# Altium schematic sheet [08] - CAN INTERFACE.SchDoc("[08] - CAN INTERFACE")
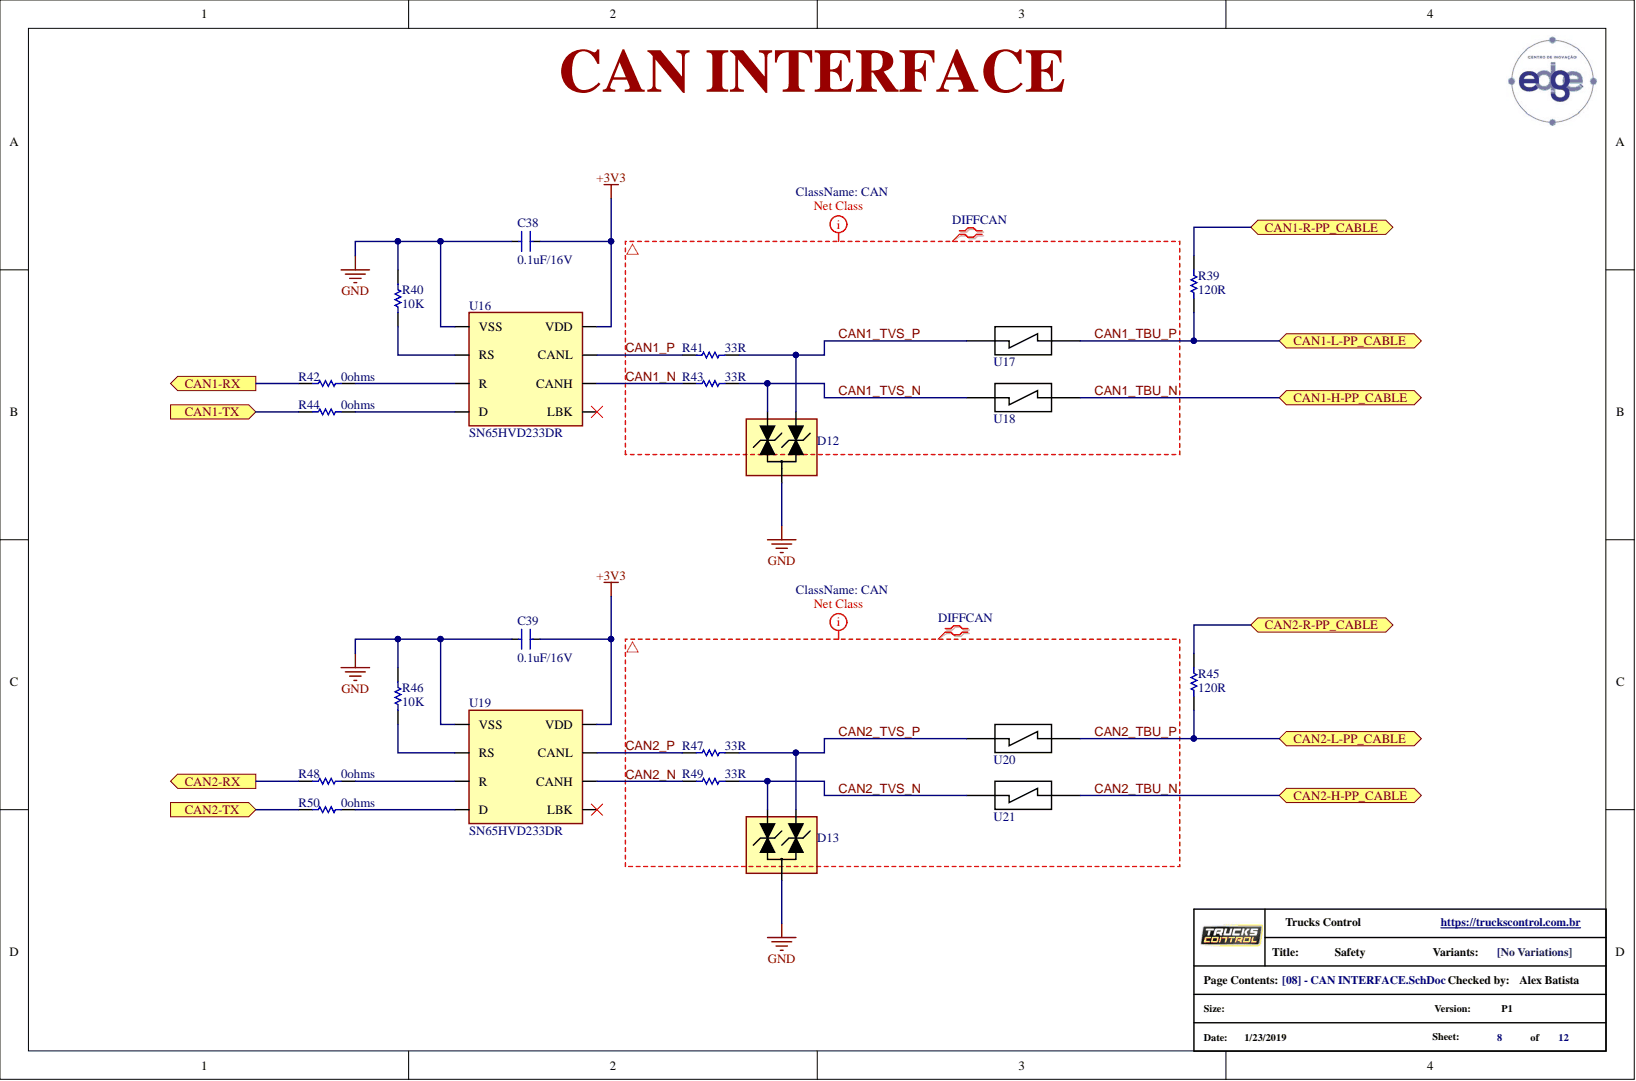
[diagram p0: [08] - CAN INTERFACE.SchDoc]

## Parts
C38  0.1uF/16V  [C0402]
C39  0.1uF/16V  [C0402]
D12  TVS Diode  [SOT-23]  (value not drawn)
D13  TVS Diode  [SOT-23]  (value not drawn)
R39  120R  [R0402]
R40  10K  [R0402]
R41  33R  [R0402]
R42  0ohms  [R0402]
R43  33R  [R0402]
R44  0ohms  [R0402]
R45  120R  [R0402]
R46  10K  [R0402]
R47  33R  [R0402]
R48  0ohms  [R0402]
R49  33R  [R0402]
R50  0ohms  [R0402]
U16  SN65HVD233DR  Case/Package: D  [SN65HVD233DR]
U17  TBU  [DFN-3]  (value not drawn)
U18  TBU  [DFN-3]  (value not drawn)
U19  SN65HVD233DR  Case/Package: D  [SN65HVD233DR]
U20  TBU  [DFN-3]  (value not drawn)
U21  TBU  [DFN-3]  (value not drawn)

## Ports
CAN1-H-PP_CABLE, CAN1-L-PP_CABLE, CAN1-R-PP_CABLE, CAN1-RX, CAN1-TX, CAN2-H-PP_CABLE, CAN2-L-PP_CABLE, CAN2-R-PP_CABLE, CAN2-RX, CAN2-TX

## Nets
+3V3: C38.1 C39.1 U16.3(VDD) U19.3(VDD)
GND: C38.2 C39.2 D12.3 D13.3 R40.2 R46.2 U16.2(VSS) U19.2(VSS)
CAN1-R-PP_CABLE: R39.2 ; port CAN1-R-PP_CABLE
CAN1-RX: R42.1 ; port CAN1-RX
CAN1-TX: R44.1 ; port CAN1-TX
CAN1_N: R43.1 U16.7(CANH)
CAN1_P: R41.1 U16.6(CANL)
CAN1_TBU_N: U18.3 ; port CAN1-H-PP_CABLE
CAN1_TBU_P: R39.1 U17.3 ; port CAN1-L-PP_CABLE
CAN1_TVS_N: D12.1 R43.2 U18.1
CAN1_TVS_P: D12.2 R41.2 U17.1
CAN2-R-PP_CABLE: R45.2 ; port CAN2-R-PP_CABLE
CAN2-RX: R48.1 ; port CAN2-RX
CAN2-TX: R50.1 ; port CAN2-TX
CAN2_N: R49.1 U19.7(CANH)
CAN2_P: R47.1 U19.6(CANL)
CAN2_TBU_N: U21.3 ; port CAN2-H-PP_CABLE
CAN2_TBU_P: R45.1 U20.3 ; port CAN2-L-PP_CABLE
CAN2_TVS_N: D13.1 R49.2 U21.1
CAN2_TVS_P: D13.2 R47.2 U20.1
NetR40_1: R40.1 U16.8(RS)
NetR42_2: R42.2 U16.4(R)
NetR44_2: R44.2 U16.1(D)
NetR46_1: R46.1 U19.8(RS)
NetR48_2: R48.2 U19.4(R)
NetR50_2: R50.2 U19.1(D)
N00174:
N00175:
N00176:
N00177:

Not connected: U16 5(LBK); U19 5(LBK)

## Library data of the parts
C38 C39 = GCM155R71C104KA55D: 0.1UF 16V X7R 0402 CAP CER; Manufacturer: Murata; Tolerance: 10%; Capacitance: 100nF; Width: 0.02inch; Voltage Rating (DC): 16V; Voltage Rating: 16V; Voltage: 16V; Thickness: 0.022inch; Termination: SMD/SMT; Supplier Part Number 1: 81-GCM155R71C104KA5D; Supplier 1: Mouser; Stock 1: 3750168; REACH SVHC: No SVHC; Ratings: AEC-Q200; Radiation Hardening: No; Pricing 1: 1=0.1, 10=0.061, 50=0.061, 100=0.026, 1000=0.015, 10000=0.01 (USD); Packaging: Tape and Reel; Package Quantity: 1; Mount: Surface Mount; Min Operating Temperature: -55°C; Max Operating Temperature: 125°C; Manufacturer Part Number: GCM155R71C104KA55D; Length: 1mm; Lead Free: Lead Free; Height: 0.5mm; Dielectric: X7R; Depth: 0.5mm; Component Kind: Standard; Case Code (Metric): 1005; Case Code (Imperial): 0402; Case/Package: 0402; Library Name: tc-pcb-safety_V1I1.SchLib
D12 D13 = CDSOT23-SM712: TVS DIODE 7VWM/12VWM SOT23; Manufacturer: Bourns; Working Voltage: 12V; Test Current: 1mA; Reverse Breakdown Voltage: 13.3V; Power Line Protection: No; Power Dissipation: 400W; Polarity: Bidirectional,Unidirectional; Peak Pulse Current: 17A; Number of Elements: 2; Max Surge Current: 30A; Max Reverse Leakage Current: 20uA; Max Power Dissipation: 600W; ESD Protection: Yes; Element Configuration: Dual; Direction: Bidirectional; Clamping Voltage: 26V; Capacitance: 75pF; Width: 0.055inch; Termination: SMD/SMT; Supplier Part Number 1: 652-CDSOT23-SM712; Supplier 1: Mouser; Stock 1: 8506; Reverse Standoff Voltage: 12V; REACH SVHC: No SVHC; Radiation Hardening: No; Pricing 1: 1=1.7, 10=1.37, 50=1.37, 100=1.05, 1000=0.731, 10000=0.63 (USD); Peak Pulse Power: 400W; Packaging: Tape and Reel; Package Quantity: 1; Number of Pins: 3; Number of Channels: 2; Number of Bidirectional Channels: 2; Mount: Surface Mount; Min Operating Temperature: -55°C; Min Breakdown Voltage: 13.3V; Max Operating Temperature: 150°C; Max Breakdown Voltage: 13.3V; Manufacturer Part Number: CDSOT23-SM712; Length: 3.04mm; Leakage Current: 20uA; Height: 1.11mm; Depth: 1.4mm; Contact Plating: Tin; Composition: Zener; Component Kind: Standard; Case/Package: SOT-23; Breakdown Voltage: 13.3V; Library Name: tc-pcb-safety_V1I1.SchLib
R39 R45 = RC0402FR-07120RL: 120 OHM 1% 50V 1/16W 0402; Manufacturer: Yageo; Temperature Coefficient: 100ppm/°C; Power Rating: 62.5mW; Max Power Dissipation: 63mW; Width: 0.02inch; Voltage Rating: 50V; Tolerance: 1%; Termination: SMD/SMT; Supplier Part Number 2: 311-120LRCT-ND; Supplier Part Number 1: 603-RC0402FR-07120RL; Supplier 2: Digi-Key; Supplier 1: Mouser; Stock 2: 1301674; Stock 1: 14774; RoHS: Yes; Resistance: 120R; REACH SVHC: No SVHC; Pricing 2: 1 = 0.10000, 10 = 0.01600, 100 = 0.00670, 1000 = 0.00301, 2500 = 0.00261, 5000 = 0.00215 (USD); Pricing 1: 1 = 0.10000, 10 = 0.01200, 50 = 0.01200, 100 = 0.00400, 1000 = 0.00300, 10000 = 0.00300 (USD); Packaging: Tape and Reel; Package Quantity: 1; Number of Terminations: 2; Min Operating Temperature: -55°C; Military Standard: Not; Max Operating Temperature: 155°C; Manufacturer Part Number: RC0402FR-07120RL; Length: 1mm; Lead Free: Lead Free; Height: 0.016inch; Features: Moisture Resistant; Depth: 0.5mm; Composition: Thick Film; ComponentLink6URL: http://www.yageo.com/documents/recent/PYu-RC0402_51_RoHS_L_6_r.pdf; ComponentLink6Description: http://www.yageo.com/documents/recent/PYu-RC0402_51_RoHS_L_6_r.pdf; ComponentLink5URL: http://www.yageo.com/documents/recent/PYu-RC_Group_51_RoHS_L_10.pdf; ComponentLink5Description: http://www.yageo.com/documents/recent/PYu-RC_Group_51_RoHS_L_10.pdf; ComponentLink4URL: http://www.yageo.com/documents/recent/PYu-RC_Group_51_RoHS_L_9.pdf; ComponentLink4Description: http://www.yageo.com/documents/recent/PYu-RC_Group_51_RoHS_L_9.pdf; ComponentLink3URL: http://documents.rs-components.com/techlib://itc_doclist_GB.htm?stockno=6185898; ComponentLink3Description: http://documents.rs-components.com/techlib://itc_doclist_GB.htm?stockno=6185898; ComponentLink2URL: http://www.mouser.com/ds/2/447/PYu-RC0402_51_RoHS_L_6_r-8721.pdf; ComponentLink2Description: http://www.mouser.com/ds/2/447/PYu-RC0402_51_RoHS_L_6_r-8721.pdf; ComponentLink1URL: http://www.farnell.com/datasheets/1018594.pdf; ComponentLink1Description: http://www.farnell.com/datasheets/1018594.pdf; Component Kind: Standard; Case Code (Metric): 1005; Case Code (Imperial): 0402; Case/Package: 0402; Library Name: tc-pcb-safety_V1I1.SchLib
R40 R46 = RC0402JR-7D10KL: 10K OHM 5% 1/16W 0402; Manufacturer: Yageo; Supplier Part Number 1: 603-RC0402JR-7D10KL; Supplier 1: Mouser; Stock 1: 178452; Pricing 1: 1=0.1, 10=0.01, 50=0.01, 100=0.004, 1000=0.003, 10000=0.002 (USD); Manufacturer Part Number: RC0402JR-7D10KL; Component Kind: Standard; Library Name: tc-pcb-safety_V1I1.SchLib
R41 R43 R47 R49 = RC0402JR-0733RL: 33 OHM 50V 5% 1/16W 0402; Manufacturer: Yageo; Temperature Coefficient: 100ppm/°C; Power Rating: 62.5mW; Max Power Dissipation: 63mW; Width: 0.5mm; Voltage Rating: 50V; Tolerance: 5%; Termination: SMD/SMT; Supplier Part Number 2: 311-33JRCT-ND; Supplier Part Number 1: 603-RC0402JR-0733RL; Supplier 2: Digi-Key; Supplier 1: Mouser; Stock 2: 5669528; Stock 1: 50; RoHS: Yes; Resistance: 33R; REACH SVHC: Unknown; Pricing 2: 1 = 0.10000, 10 = 0.01300, 100 = 0.00550, 1000 = 0.00245, 2500 = 0.00212, 5000 = 0.00176 (USD); Pricing 1: 1 = 0.10000, 10 = 0.01000, 50 = 0.01000, 100 = 0.00400, 1000 = 0.00300, 10000 = 0.00200 (USD); Packaging: Tape and Reel; Package Quantity: 1; Number of Terminations: 2; Min Operating Temperature: -55°C; Military Standard: Not; Max Operating Temperature: 155°C; Manufacturer Part Number: RC0402JR-0733RL; Length: 1mm; Lead Free: Lead Free; Height: 0.016inch; Features: Moisture Resistant; Depth: 0.5mm; Composition: Thick Film; ComponentLink3URL: http://www.yageo.com/documents/recent/PYu-RC_Group_51_RoHS_L_10.pdf; ComponentLink3Description: http://www.yageo.com/documents/recent/PYu-RC_Group_51_RoHS_L_10.pdf; ComponentLink2URL: http://www.farnell.com/datasheets/1018594.pdf; ComponentLink2Description: http://www.farnell.com/datasheets/1018594.pdf; ComponentLink1URL: http://www.yageo.com/documents/recent/PYu-RC0402_51_RoHS_L_6_r.pdf; ComponentLink1Description: http://www.yageo.com/documents/recent/PYu-RC0402_51_RoHS_L_6_r.pdf; Component Kind: Standard; Case Code (Metric): 1005; Case Code (Imperial): 0402; Case/Package: 0402; Library Name: tc-pcb-safety_V1I1.SchLib
R42 R44 R48 R50 = CRG0402ZR: 0 ohm, 50 V, 0402 [1005 Metric], 63 mW, CRG Series; Manufacturer: TE Connectivity; Voltage Rating (DC): 50V; Tolerance: 0%; Temperature Coefficient: 200ppm/°C; Resistance: 0mR; Power Rating: 63mW; Number of Resistors: 1; Insulation Resistance: 1GR; Width: 0.5mm; Weight: 2.3E-05oz; Voltage Rating: 50V; Termination: Solder; Supplier Part Number 1: 279-CRG0402ZR; Supplier 1: Mouser; Stock 1: 540000; REACH SVHC: Unknown; Radiation Hardening: No; Pricing 1: 10000=0.002 (USD); Packaging: Tape and Reel; Package Quantity: 10000; Number of Terminations: 2; Number of Pins: 2; Mount: Surface Mount; Min Operating Temperature: -55°C; Max Operating Temperature: 125°C; Manufacturer Part Number: CRG0402ZR; Length: 1mm; Height: 0.35mm; ELV: Compliant; Depth: 0.5mm; Composition: Thick Film; Component Kind: Standard; Case Code (Metric): 1005; Case Code (Imperial): 0402; Case/Package: 0402; Library Name: tc-pcb-safety_V1I1.SchLib
U16 U19 = SN65HVD233DR: 3.3V CAN Transceiver with Standby Mode, Loop-back, 6 mA, -40 to 125 degC, 8-pin SOIC (D), Green (RoHS & no Sb/Br); Manufacturer: Texas Instruments; Simplex/Duplex: Half Duplex; Receiver Hysteresis: 100mV; Number of Transmit Buffers: 1; Nominal Supply Current: 6mA; Min Supply Voltage: 3V; Max Supply Voltage: 3.6V; Data Rate: 1Mbps; Weight: 0.002561oz; Supplier Part Number 2: 296-35967-1-ND; Supplier Part Number 1: 595-SN65HVD233DR; Supplier 2: Digi-Key; Supplier 1: Mouser; Stock 2: 65613; Stock 1: 46137; RoHS: Yes; REACH SVHC: No SVHC; Radiation Hardening: No; Pricing 2: 1 = 2.69000, 10 = 2.41700, 100 = 1.98040, 500 = 1.68586, 1000 = 1.42181 (USD); Pricing 1: 1 = 2.91000, 10 = 2.47000, 50 = 2.47000, 100 = 1.98000, 1000 = 1.43000, 10000 = 1.29000 (USD); Packaging: Tape and Reel; Package Quantity: 2500; Operating Supply Voltage: 3.3V; Number of Transceivers: 1; Number of Receivers: 1; Number of Receive Buffers: 1; Number of Pins: 8; Number of Drivers: 1; Min Operating Temperature: -40°C; Max Supply Current: 6mA; Max Operating Temperature: 125°C; Manufacturer Part Number: SN65HVD233DR; Lead Free: Lead Free; Contact Plating: Gold; ComponentLink1URL: http://www.ti.com/lit/ds/symlink/sn65hvd233.pdf; ComponentLink1Description: http://www.ti.com/lit/ds/symlink/sn65hvd233.pdf; Component Kind: Standard; Library Name: tc-pcb-safety_V1I1.SchLib
U17 U18 U20 U21 = TBU-CA025-050-WH: SURGE SUPP TBU 50MA 250VIMP SMD; Manufacturer: Bourns; Working Voltage: 100V; Voltage Rating (DC): 250V; Reverse Standoff Voltage: 20V; Response Time: 1us; Peak Pulse Current: 100mA; Number of Circuits: 2; ESD Protection: Yes; Element Configuration: Single; Direction: Bidirectional; Width: 0.157inch; Weight: 0.076oz; Voltage Rating: 250V; Termination: Solder; Supplier Part Number 1: 652-TBU-CA025-050-WH; Supplier 1: Mouser; Stock 1: 23479; REACH SVHC: No SVHC; Pricing 1: 1=1.84, 10=1.49, 50=1.49, 100=1.19, 1000=0.863, 10000=0.77 (USD); Packaging: Tape and Reel; Package Quantity: 3000; Number of Pins: 12; Mount: Surface Mount; Min Operating Temperature: -40°C; Max Operating Temperature: 125°C; Manufacturer Part Number: TBU-CA025-050-WH; Length: 0.255inch; Height: 0.033inch; Current Rating: 50mA; Component Kind: Standard; Clamping Voltage: 250V; Case/Package: DFN; Library Name: tc-pcb-safety_V1I1.SchLib
